# Altium schematic sheet universal_addon_revA.SchDoc(universal_addon_revA)
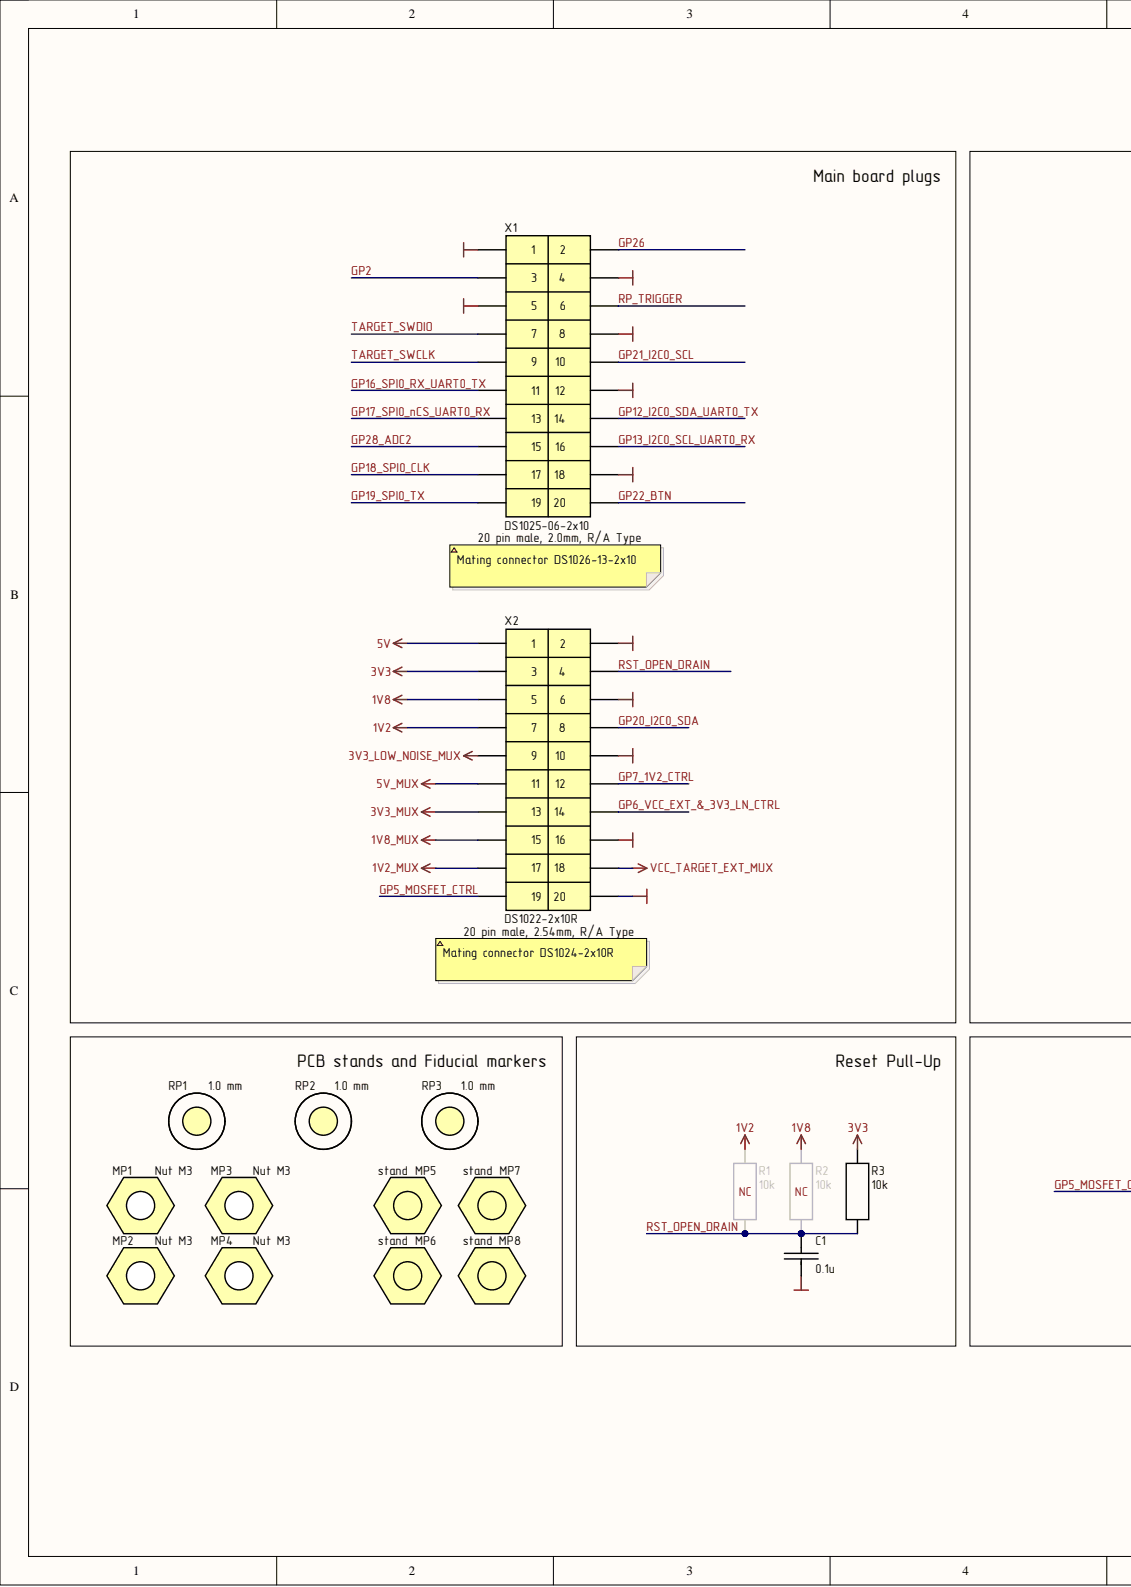
[diagram p0: universal_addon_revA.SchDoc, left]
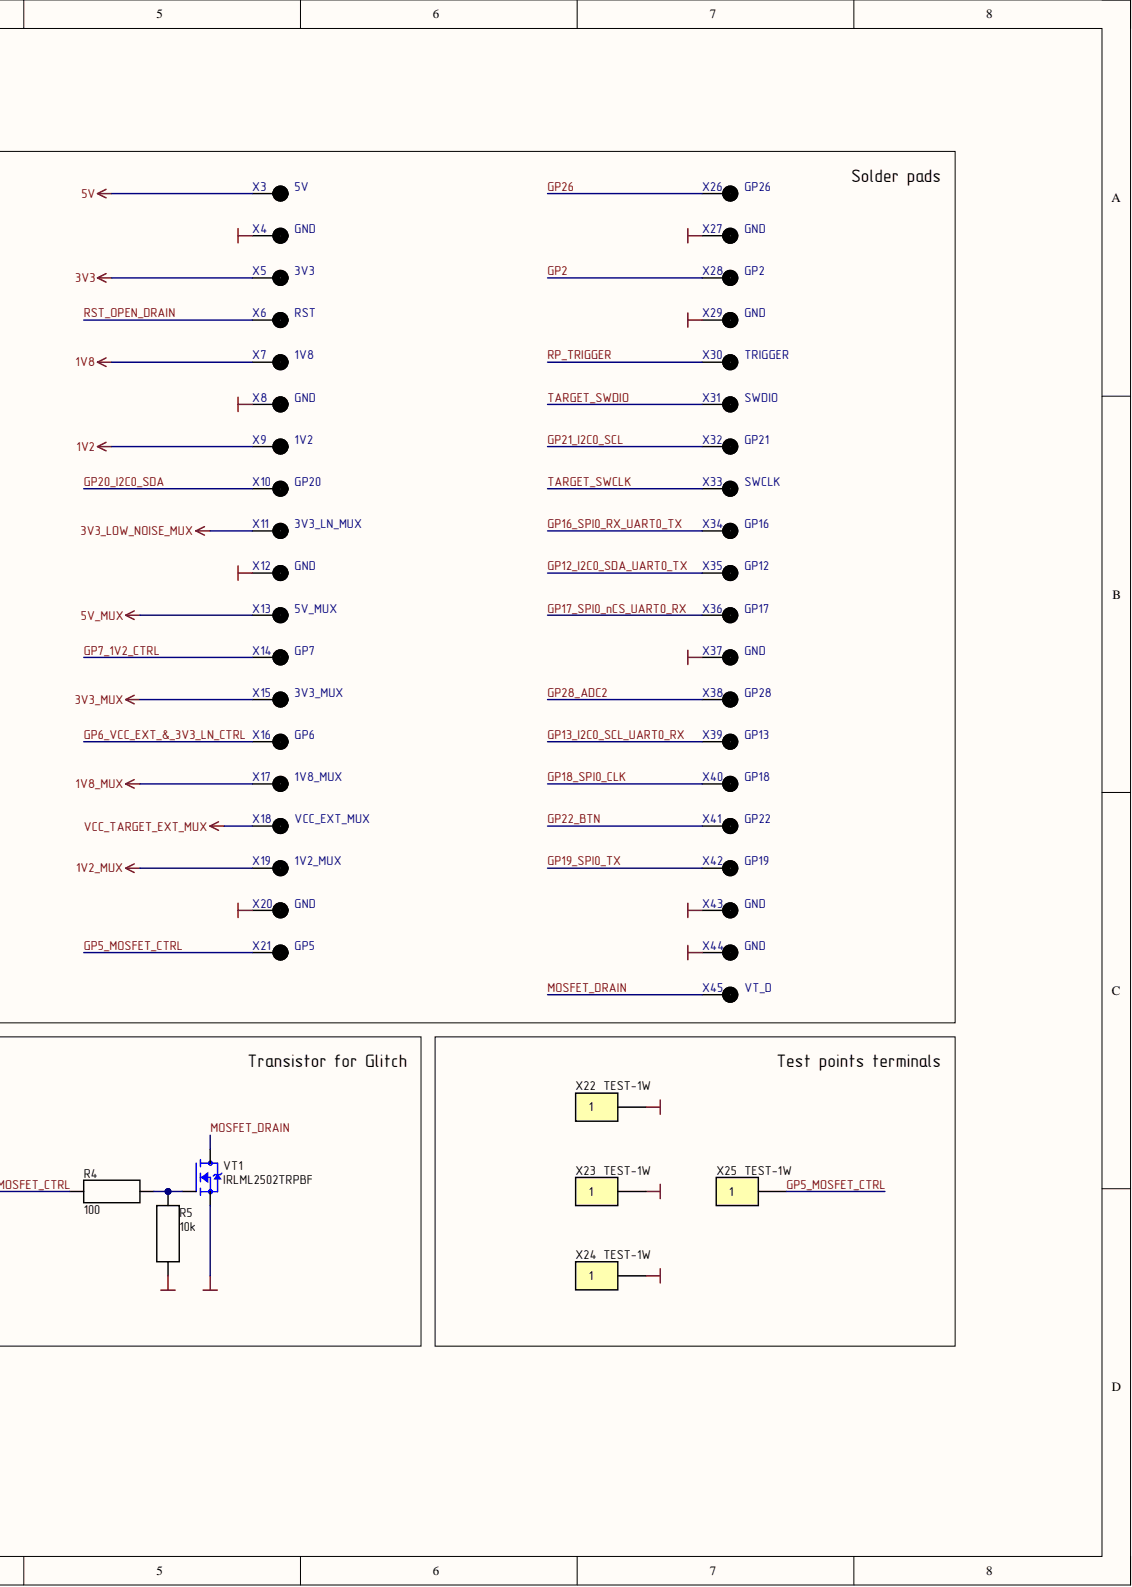
[diagram p1: universal_addon_revA.SchDoc, right]

## Parts
C1  value 0.1u  [CH0603C]  (hidden comment: Ceramic capacitor, 0603, 0.1u, ±10%, 50V, X7R, -55...+125°C)
MP1  Nut M3  [Stand]  (mechanical)
MP2  Nut M3  [Stand]  (mechanical)
MP3  Nut M3  [Stand]  (mechanical)
MP4  Nut M3  [Stand]  (mechanical)
MP5  stand  [Stand]
MP6  stand  [Stand]
MP7  stand  [Stand]
MP8  stand  [Stand]
R1  value 10k  [CH0603R]  (hidden comment: Resistor thick-film, 0603, 10k, ±5%, 0.1W, -55...+125°C)
R2  value 10k  [CH0603R]  (hidden comment: Resistor thick-film, 0603, 10k, ±5%, 0.1W, -55...+125°C)
R3  value 10k  [CH0603R]  (hidden comment: Resistor thick-film, 0603, 10k, ±5%, 0.1W, -55...+125°C)
R4  value 100  [CH0603R]  (hidden comment: Resistor thick-film, 0603, 100, ±1%, 0.1W, -55...+125°C)
R5  value 10k  [CH0603R]  (hidden comment: Resistor thick-film, 0603, 10k, ±1%, 0.1W, -55...+125°C)
RP1  1.0 mm  [REPER-10]  (no BOM)
RP2  1.0 mm  [REPER-10]  (no BOM)
RP3  1.0 mm  [REPER-10]  (no BOM)
VT1  IRLML2502TRPBF  [SOT23]
X1  DS1025-06-2x10  [DS1025-06-2x10]
X2  DS1022-2x10R  [DS1022-2x10R]
X3  5V  [Test point mid]  (no BOM)
X4  GND  [Test point mid]  (no BOM)
X5  3V3  [Test point mid]  (no BOM)
X6  RST  [Test point mid]  (no BOM)
X7  1V8  [Test point mid]  (no BOM)
X8  GND  [Test point mid]  (no BOM)
X9  1V2  [Test point mid]  (no BOM)
X10  GP20  [Test point mid]  (no BOM)
X11  3V3_LN_MUX  [Test point mid]  (no BOM)
X12  GND  [Test point mid]  (no BOM)
X13  5V_MUX  [Test point mid]  (no BOM)
X14  GP7  [Test point mid]  (no BOM)
X15  3V3_MUX  [Test point mid]  (no BOM)
X16  GP6  [Test point mid]  (no BOM)
X17  1V8_MUX  [Test point mid]  (no BOM)
X18  VCC_EXT_MUX  [Test point mid]  (no BOM)
X19  1V2_MUX  [Test point mid]  (no BOM)
X20  GND  [Test point mid]  (no BOM)
X21  GP5  [Test point mid]  (no BOM)
X22  PartNumber: TEST-1W  [TEST-1W 1pin MULTICOMP]  (hidden comment: GND)
X23  PartNumber: TEST-1W  [TEST-1W 1pin MULTICOMP]  (hidden comment: GND)
X24  PartNumber: TEST-1W  [TEST-1W 1pin MULTICOMP]  (hidden comment: GND)
X25  GP5  PartNumber: TEST-1W  [TEST-1W 1pin MULTICOMP]
X26  GP26  [Test point mid]  (no BOM)
X27  GND  [Test point mid]  (no BOM)
X28  GP2  [Test point mid]  (no BOM)
X29  GND  [Test point mid]  (no BOM)
X30  TRIGGER  [Test point mid]  (no BOM)
X31  SWDIO  [Test point mid]  (no BOM)
X32  GP21  [Test point mid]  (no BOM)
X33  SWCLK  [Test point mid]  (no BOM)
X34  GP16  [Test point mid]  (no BOM)
X35  GP12  [Test point mid]  (no BOM)
X36  GP17  [Test point mid]  (no BOM)
X37  GND  [Test point mid]  (no BOM)
X38  GP28  [Test point mid]  (no BOM)
X39  GP13  [Test point mid]  (no BOM)
X40  GP18  [Test point mid]  (no BOM)
X41  GP22  [Test point mid]  (no BOM)
X42  GP19  [Test point mid]  (no BOM)
X43  GND  [Test point mid]  (no BOM)
X44  GND  [Test point mid]  (no BOM)
X45  VT_D  [Test point mid]  (no BOM)

## Nets
1V2: R1.2 X2.7 X9.1
1V2_MUX: X2.17 X19.1
1V8: R2.2 X2.5 X7.1
1V8_MUX: X2.15 X17.1
3V3: R3.2 X2.3 X5.1
3V3_LOW_NOISE_MUX: X2.9 X11.1
3V3_MUX: X2.13 X15.1
5V: X2.1 X3.1
5V_MUX: X2.11 X13.1
GND: C1.1 R5.1 VT1.2 X1.1 X1.4 X1.5 X1.8 X1.12 X1.18 X2.2 X2.6 X2.10 X2.16 X2.20 X4.1 X8.1 X12.1 X20.1 X22.1 X23.1 X24.1 X27.1 X29.1 X37.1 X43.1 X44.1
VCC_TARGET_EXT_MUX: X2.18 X18.1
GP2: X1.3 X28.1
GP5_MOSFET_CTRL: R4.1 X2.19 X21.1 X25.1
GP6_VCC_EXT_&_3V3_LN_CTRL: X2.14 X16.1
GP7_1V2_CTRL: X2.12 X14.1
GP12_I2C0_SDA_UART0_TX: X1.14 X35.1
GP13_I2C0_SCL_UART0_RX: X1.16 X39.1
GP16_SPI0_RX_UART0_TX: X1.11 X34.1
GP17_SPI0_nCS_UART0_RX: X1.13 X36.1
GP18_SPI0_CLK: X1.17 X40.1
GP19_SPI0_TX: X1.19 X42.1
GP20_I2C0_SDA: X2.8 X10.1
GP21_I2C0_SCL: X1.10 X32.1
GP22_BTN: X1.20 X41.1
GP26: X1.2 X26.1
GP28_ADC2: X1.15 X38.1
MOSFET_DRAIN: VT1.3 X45.1
RP_TRIGGER: X1.6 X30.1
RST_OPEN_DRAIN: C1.2 R1.1 R2.1 R3.1 X2.4 X6.1
TARGET_SWCLK: X1.9 X33.1
TARGET_SWDIO: X1.7 X31.1
NetR4_2: R4.2 R5.2 VT1.1

Not connected: MP5 1; MP6 1; MP7 1; MP8 1; RP1 1; RP2 1; RP3 1

## Library data of the parts
C1 = Capacitor: Manufacturer: Murata; PartNumber: GRM188R71H104K; Type: SMD; Component Kind: Standard; ComponentType: Ceramic capacitor; Description/Value: Ceramic capacitor, 0603, 0.1u, ±10%, 50V, X7R, -55...+125°C; HelpURL: ?; Library Name: Common Lib.SchLib; Package: 0603; Temperature: -55...+125°C; Tolerance: ±10%; TypeDielectric: X7R; Voltage: 50V
MP1-MP4 = nut: PartNumber: Nut M3 DIN 934; Description/Value: Hexagon Nut M3 DIN 934; Library Name: Common Lib.SchLib
MP5-MP8 = stand: PartNumber: PCHSN-10; Description/Value: PCB brass stand, M3, 10mm; Component Kind: Standard; Library Name: Common Lib.SchLib
R1-R3 = Resistor: Manufacturer: Yageo; PartNumber: RC0603JR-0710KL; Component Kind: Standard; ComponentType: Resistor thick-film; Description/Value: Resistor thick-film, 0603, 10k, ±5%, 0.1W, -55...+125°C; HelpURL: https://datasheet.lcsc.com/lcsc/2009261810_YAGEO-RL0805FR-070R33L_C724024.pdf; Library Name: Common Lib.SchLib; Package: 0603; Power: 0.1W; Temperature: -55...+125°C; Tolerance: ±5%; Type: SMD
R4 = Resistor: Manufacturer: Yageo; PartNumber: RC0603FR-07100RL; Component Kind: Standard; ComponentType: Resistor thick-film; Description/Value: Resistor thick-film, 0603, 100, ±1%, 0.1W, -55...+125°C; HelpURL: https://datasheet.lcsc.com/lcsc/2009261810_YAGEO-RL0805FR-070R33L_C724024.pdf; Library Name: Common Lib.SchLib; Package: 0603; Power: 0.1W; Temperature: -55...+125°C; Tolerance: ±1%; Type: SMD
R5 = Resistor: Manufacturer: Yageo; PartNumber: RC0603FR-0710KL; Component Kind: Standard; ComponentType: Resistor thick-film; Description/Value: Resistor thick-film, 0603, 10k, ±1%, 0.1W, -55...+125°C; HelpURL: https://datasheet.lcsc.com/lcsc/2009261810_YAGEO-RL0805FR-070R33L_C724024.pdf; Library Name: Common Lib.SchLib; Package: 0603; Power: 0.1W; Temperature: -55...+125°C; Tolerance: ±1%; Type: SMD
RP1-RP3 = CMP-00005-2: Design Item ID: REPER; Source: Common Lib.SchLib; Library Name: Positive Labs Server
VT1 = MOSFET N: RoHS Compliant | Industry-leading quality | Fast Switching | Low Profile _less than 1.1mm_ | SOT-23 Footprint; Description/Value: N-MOSFET Transistor, 20V, 4.2A, SOT-23-23; Component Kind: Standard; Library Name: Common Lib.SchLib; Package: SOT-23; PartNumber: IRLML2502TRPBF
X1 = 20-Connector: Description/Value: PLD Connector 20 pin, 2.0mm, Male, DS1025-06-2x10; Component Kind: Standard; ComponentKind: PLD Connector 20 pin, 2.0mm, Male; Library Name: Common Lib.SchLib; PartNumber: DS1025-06-2x10
X2 = 20-Connector: Description/Value: PLD Connector 20 pin, 2.54mm, Male, DS1022-2x10R; Component Kind: Standard; ComponentKind: PLD Connector 20 pin, 2.54mm, Male; Library Name: Common Lib.SchLib; PartNumber: DS1022-2x10R
X3-X21 X26-X45 = CMP-00060-2: Design Item ID: Test Point; Source: Common Lib.SchLib; Library Name: Positive Labs Server
X22-X25 = 1-Connector: Description/Value: PCB Test Point Through Hole Mount; Component Kind: Standard; Library Name: Common Lib.SchLib
